# Altium schematic sheet Serial.SchDoc
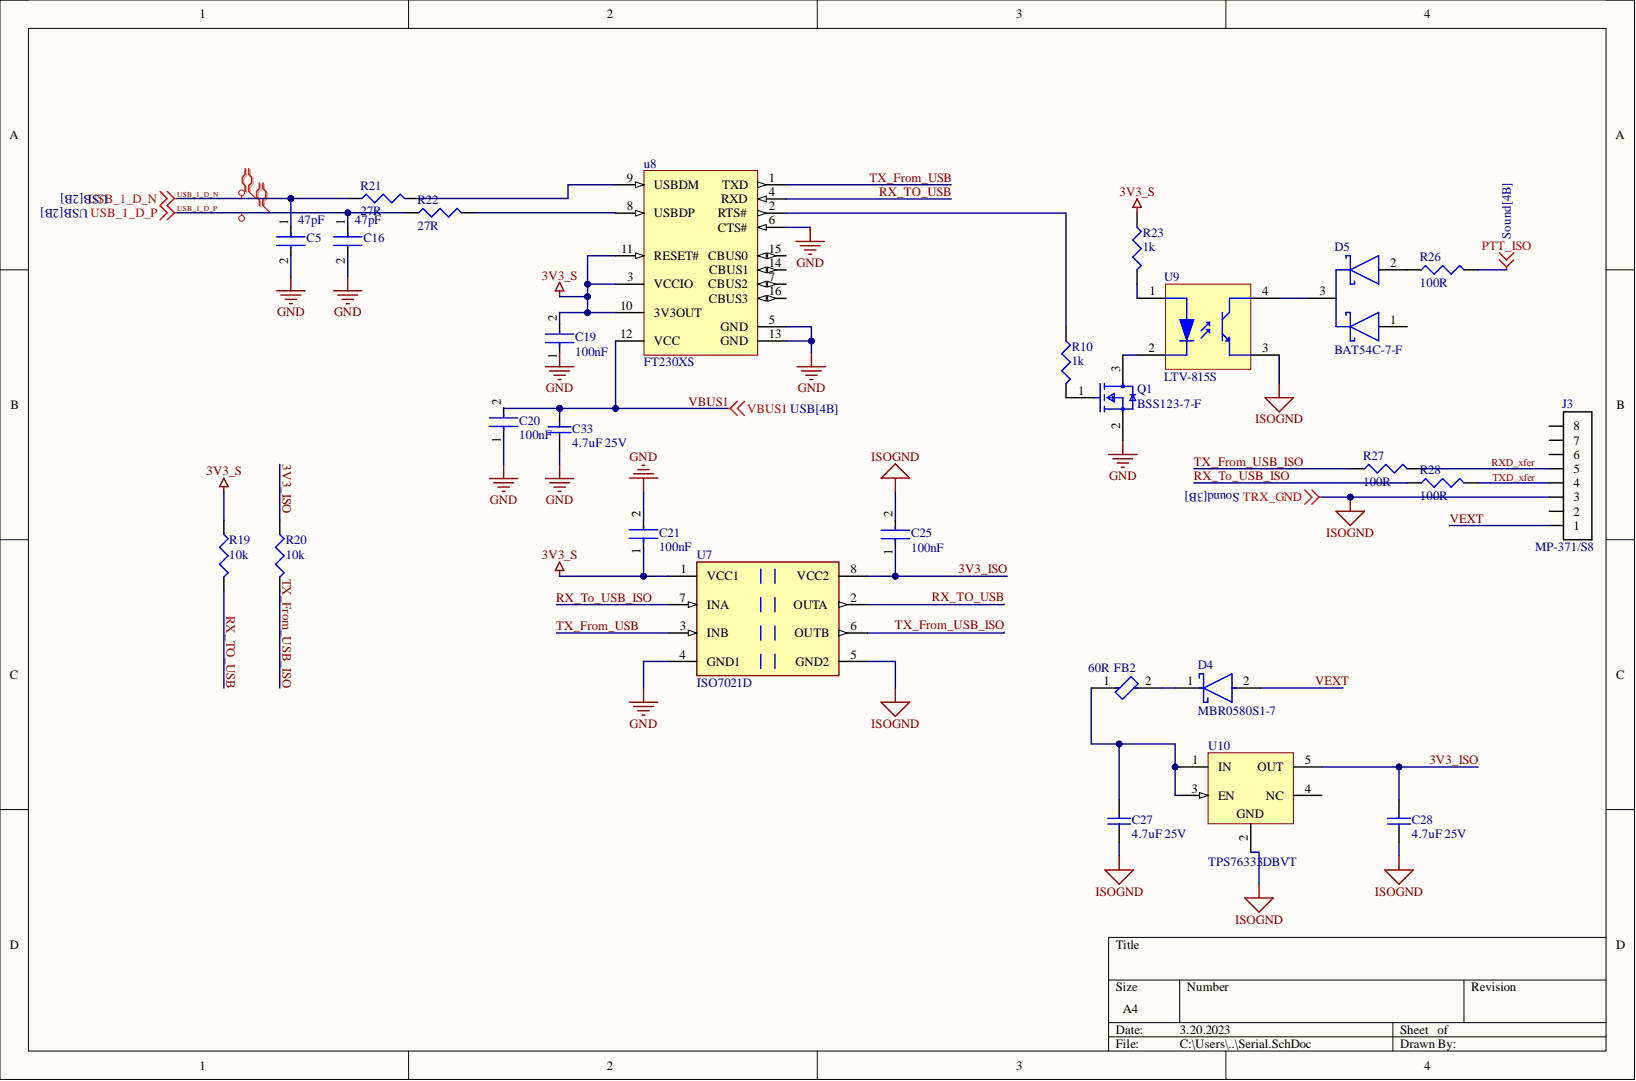
[diagram p0: Serial.SchDoc]

## Parts
C5  Capacitance: 47pF  [FP-CL10-IPC_C]  (hidden comment: CL10C470JC8NNNC)
C16  Capacitance: 47pF  [FP-CL10-IPC_C]  (hidden comment: CL10C470JC8NNNC)
C19  Capacitance: 100nF  [FP-CL10-IPC_B]  (hidden comment: CL10B104KC8NNNC)
C20  Capacitance: 100nF  [FP-CL10-IPC_B]  (hidden comment: CL10B104KC8NNNC)
C21  Capacitance: 100nF  [FP-CL10-IPC_B]  (hidden comment: CL10B104KC8NNNC)
C25  Capacitance: 100nF  [FP-CL10-IPC_B]  (hidden comment: CL10B104KC8NNNC)
C27  4.7uF 25V  value 4.7uF  [CAPC1210(3225)220_M]
C28  4.7uF 25V  value 4.7uF  [CAPC1210(3225)220_M]
C33  4.7uF 25V  value 4.7uF  [CAPC1210(3225)220_M]
D4  MBR0580S1-7  [FP-SOD123-MFG]
D5  BAT54C-7-F  [FP-SOT23-IPC_B]
FB2  Impedance: 60R  [FP-BLM41-0_2-IPC_B]  (hidden comment: BLM41PG600SN1L)
J3  MP-371/S8  [MiniDIN8_TopSolder_Lumberg]
Q1  BSS123-7-F  [FP-SOT23-IPC_C]
R10  1k  [J1-0603]
R19  10k  [J1-0603]
R20  10k  [J1-0603]
R21  27R  [J1-0603]
R22  27R  [J1-0603]
R23  1k  [J1-0603]
R26  100R  [J1-0603]
R27  100R  [J1-0603]
R28  100R  [J1-0603]
U7  ISO7021D  [FP-D0008A-IPC_B]
u8  FT230XS  [FTDI-SSOP-16_L]
U9  LTV-815S  [LTST-LTV-817S-4_V]
U10  TPS76333DBVT  [DBV0005A_N]

## Nets
3V3_S: C19.2 C21.1 R19.1 R23.1 U7.1(VCC1) u8.3(VCCIO) u8.10(3V3OUT) u8.11(RESET#)
GND: C5.2 C16.2 C19.1 C20.1 C21.2 C33.2 Q1.2 U7.4(GND1) u8.5(GND) u8.6(CTS#) u8.13(GND)
PTT_ISO: R26.2
TRX_GND: C25.2 C27.2 C28.2 J3.3 U7.5(GND2) U9.3 U10.2
3V3_ISO: C25.1 C28.1 R20.1 U7.8(VCC2) U10.5(OUT)
RX_TO_USB: R19.2 U7.2(OUTA) u8.4(RXD)
RX_To_USB_ISO: R28.1 U7.7(INA)
RXD_xfer: J3.5 R27.2
TX_From_USB: U7.3(INB) u8.1(TXD)
TX_From_USB_ISO: R20.2 R27.1 U7.6(OUTB)
TXD_xfer: J3.4 R28.2
USB_1_D_N: C5.1 R21.1
USB_1_D_P: C16.1 R22.1
VBUS1: C20.2 C33.1 u8.12(VCC)
VEXT: D4.2 J3.1
NetC27_1: C27.1 FB2.1 U10.1(IN) U10.3(EN)
NetD4_1: D4.1 FB2.2
NetD5_2: D5.2 R26.1
NetD5_3: D5.3 U9.4
NetQ1_1: Q1.1 R10.2
NetQ1_3: Q1.3 U9.2
NetR10_1: R10.1 u8.2(RTS#)
NetR21_2: R21.2 u8.9(USBDM)
NetR22_2: R22.2 u8.8(USBDP)
NetR23_2: R23.2 U9.1
Netu8_7: u8.7(CBUS2) U10.4(NC)

Not connected: D5 1; J3 2 6 7 8; u8 14(CBUS1) 15(CBUS0) 16(CBUS3)

## Library data of the parts
C5 C16 = CMP-13271-001416-1: Cap Ceramic 47pF 100V C0G ±5% Pad SMD 0603 +125°C T/R; Case Code (Imperial): 0603; Case Code (Metric): 1608; Case/Package: 0603; Component Kind: Standard; Depth: 0.8mm; Dielectric: C0G; Height: 0.8mm; JLCPCBPartNum: C1671; Length: 1.6mm; Library Name: Altium Content Vault; Material: Ceramic; Max Operating Temperature: 125°C; Min Operating Temperature: -55°C; Mount: Surface Mount; Packaging: Tape and Reel; Radiation Hardening: No; Thickness: 0.035inch; Tolerance: 5%; Voltage Rating: 100V; Voltage Rating (DC): 100V; Width: 0.031inch
C19-C21 C25 = CMP-13271-004036-1: Cap Ceramic 100nF 100V X7R ±10% Pad SMD 0603 +125°C T/R; Case Code (Imperial): 0603; Case Code (Metric): 1608; Case/Package: 0603; Component Kind: Standard; JLCPCBPartNum: C15725; Length: 0.063inch; Library Name: Altium Content Vault; Max Operating Temperature: 125°C; Min Operating Temperature: -55°C; Mount: Surface Mount; Packaging: Cut Tape; Thickness: 0.035inch; Tolerance: 10%; Voltage Rating: 100V; Width: 0.031inch
C27 C28 C33 = CMP-1038-02151-2: CAP 4.7uF 25V ±10% 1210 (3225 Metric) Thickness 2.2mm SMD; Case-EIA: 1210; Case-Metric: 3225; Tolerance: Â±10%; Component Kind: Standard; JLCPCBPartNum: C86052; Library Name: Altium Content Vault; Max Thickness: 2.2 mm; Rated Voltage: 25 V; Technology: SMT
D4 = CMP-12790-000046-1: DIODE SCHOTTKY 80V 500MA SOD123; Manufacturer: Diodes; Capacitance: 15pF; Forward Current: 0.5A; Max Junction Temp: +175°C; Max Surge Forward Current: 14A; Peak Reverse Current: 0.5uA; Standoff Height: 0mm; Value: 15pF; Automotive: No; Category: Diode; Component Kind: Standard; ComponentLink2Description: Datasheet; ComponentLink2URL: https://www.diodes.com/assets/Datasheets/MBR0580S1.pdf; Device Class L1: Discrete Semiconductors; Device Class L2: Diodes; Device Class L3: Schottky Diodes; Digikey Description: DIODE SCHOTTKY 80V 500MA SOD123; Footprint Url: https://www.diodes.com/assets/Package-Files/SOD123.pdf; Height: 1.45mm; JLCPCBPartNum: C177047; Lead Free: Yes; Library Name: Altium Content Vault; Manufacturer Part Number: MBR0580S1-7; Max Repetitive Reverse Voltage: 80V; Mouser Description: Schottky Diodes & Rectifiers SBR 0.5A 80Vrrm 14A Ifsm 80Vrrm 15pF; Octopart: https://octopart.com/mbr0580s1-7-diodes+inc.-53081572; Package: SOD123; Reverse Voltage: 80V; RoHS: TRUE; Temperature: -55°C to +175°C; Temperature Range High: +175°C; Temperature Range Low: -55°C
D5 = CMP-12790-000084-1: DIODE ARRAY SCHOTTKY 30V SOT23-3; Average Rectified Current: 200mA; Capacitance: 10pF; Element Configuration: Common Cathode; Forward Voltage: 800mV; Max Forward Surge Current (Ifsm): 600mA; Max Power Dissipation: 200mW; Max Reverse Current: 2uA; Reverse Recovery Time: 5ns; Case/Package: SOT-23; Component Kind: Standard; Contact Plating: Tin; Current Rating: 200mA; Forward Current: 200mA; Height: 1mm; JLCPCBPartNum: C134405; Lead Free: Lead Free; Length: 2.9mm; Library Name: Altium Content Vault; Max Operating Temperature: 150°C; Max Repetitive Reverse Voltage (Vrrm): 30V; Max Reverse Voltage (DC): 30V; Min Operating Temperature: -65°C; Mount: Surface Mount; Number of Pins: 3; Package Quantity: 3000; Packaging: Tape and Reel; Peak Non-Repetitive Surge Current: 600mA; Power Dissipation: 200mW; Power Rating: 200mW; Radiation Hardening: No; REACH SVHC: No SVHC; RoHS Compliant: Yes; Series: -; Termination: SMD/SMT; Voltage Rating (DC): 30V; Weight: 0.000282oz; Width: 1.3mm
FB2 = CMP-0686-00754-3: Ferrite Bead, 1806, 60Ω @ 100MHz, 0.009Ω, 6A; Manufacturer Series: BLM41P; DC Resistance (DCR): 9mR; Number of Circuits: 1; Shielding: Unshielded; Tolerance: 25%; Case Code (Imperial): 1806; Case Code (Metric): 4516; Case/Package: 1806; Component Kind: Standard; Contact Plating: Tin; Core Material: Ferrite; Current: 6A; Current Rating: 6A; DC Current: 6A; DC Resistance-Max: 9mR; Depth: 1.6mm; Frequency: 100MHz; Height: 1.6mm; Inductor Type: FERRITE BEAD; JLCPCBPartNum: C85844; Lead Free: Lead Free; Length: 4.5mm; Library Name: Altium Content Vault; Material: Ferrite; Max Current Rating: 6A; Max DC Current: 6A; Max Operating Temperature: 125°C; Military Standard: Not; Min Operating Temperature: -55°C; Number of Functions: 1; Number of Lines: 1; Number of Pins: 2; Package Quantity: 1; Packaging: Tape and Reel; Radiation Hardening: No; REACH SVHC: No SVHC; RoHS Compliant: Yes; Series: BLM41P; Terminal Finish: Tin; Termination: SMD/SMT; Test Frequency: 100MHz; Width: 1.6mm
J3 = MiniDin8_SMT: Miniature circular connectors MP-371/S8; Component Kind: Standard; Digikey_PartNum: 2057-MDP-008-ND; Library Name: Schlib1.SchLib
Q1 = CMP-12801-000017-1: MOSFET N-CH 100V 170MA SOT23-3; Manufacturer: Diodes Incorporated; Automotive Grade: NA; Depletion Mode: False; Drain To Source Resistance: 6Ω; Gate To Source Voltage: 20V; Input Capacitace At Vds: 22pF @ 25V; Max Forward Diode Voltage: 1.3V; Max Junction Temp: +150°C; Number Of Channels: 1; Power Dissipation: 300mW; Pulse Drain Current: 680mA; Rthja Max: 417°C/W; Standoff Height: 0.013mm; Threshold Vgs Max: 2V; Threshold Vgs Min: 0.8V; Transconductance: 0.37S; Turn Off Delay Time: 13ns; Turn On Delay Time: 8ns; Typ Forward Diode Voltage: 0.84V; Automotive: No; Category: Trans; Component Kind: Standard; ComponentLink2Description: Datasheet; ComponentLink2URL: https://www.diodes.com/assets/Datasheets/ds30366.pdf; Continuous Drain Current: 170mA; Device Class L1: Discrete Semiconductors; Device Class L2: Transistors; Device Class L3: MOSFETs; Digikey Description: MOSFET N-CH 100V 170MA SOT23-3; Drain To Source Breakdown Voltage: 100V; Drain To Source Voltage: 100V; Footprint Url: https://www.diodes.com/assets/Package-Files/SOT23.pdf; Height: 1.1mm; JLCPCBPartNum: C85107; Lead Free: Yes; Library Name: Altium Content Vault; Manufacturer Part Number: BSS123-7-F; Mouser Description: MOSFET 100V 360mW; Number Of N Channels: 1; Octopart: https://octopart.com/bss123-7-f-diodes+inc.-335886; Package: SOT23; RoHS: TRUE; Temperature: -55°C to +150°C; Temperature Range High: +150°C; Temperature Range Low: -55°C
R10 R19-R23 R26-R28 = Res3: Resistor; Component Kind: Standard; LatestRevisionDate: 29-May-2009; LatestRevisionNote: IPC-7351 Footprint Added.; Library Name: Miscellaneous Devices.IntLib; PackageDescription: Chip Resistor; PackageReference: J1-0603; Published: 8-Jun-2000; Signal Integrity: Res; Simulation: RESISTOR; Publisher: Altium Limited
  R10 R23: JLCPCBPartNum: C21190; Value: 1k
  R19 R20: JLCPCBPartNum: C25804; Value: 10k
  R21 R22: JLCPCBPartNum: C192531; Value: 27R
  R26-R28: JLCPCBPartNum: C22775; Value: 100R
U7 = CMP-04872-000022-1: DGTL ISO 3000VRMS 2CH GP 8SOIC; Component Kind: Standard; Library Name: Altium Content Vault
u8 = CMP-2000-06288-1: USB to Basic UART IC, 2.97 to 5.5 V, -40 to 85 degC, 16-Pin SSOP, RoHS; Manufacturer: FTDI; Data Rate: 3Mbaud; No. of Pins: 16Pins; Supply Voltage Max: 5.5V; Supply Voltage Min: 2.97V; SVHC: NoSVHC(17-Dec-2015); USB Standard: USB2.0; USB Type: Transceiver; Component Kind: Standard; Datasheet URL: http://www.ftdichip.com/Support/Documents/DataSheets/ICs/DS_FT230X.pdf; Datasheet Version: Rev. 1.4, 05/2016; Interface Case Style: SSOP; JLCPCBPartNum: C69082; Library Name: Altium Content Vault; Manufacturer URL: http://www.ftdichip.com/; Mounting Technology: SurfaceMount; MSL: MSL3-168hours; No. of Ports: -; Operating Temperature Max: 85°C; Operating Temperature Min: -40°C; Package Description: 16-Pin Shrink Small Outline Package Integrated Circuit, Body 4.9 x 3.9 mm, Pitch 0.635 mm; Package Reference: SSOP-16; Packaging: CutTape; Product Range: -
U9 = CMP-2000-05204-1: Photocoupler - Phototransistor Output, 35 V VCEO, -50 to 110 degC, 4-Pin SMD, RoHS, Tape and Reel; Manufacturer: Lite-On; Collector Current (DC): 0.05A; Collector-Emitter Saturation Voltage: 0.2V; Collector-Emitter Voltage: 35V; Current Transfer Ratio: 600%; DELETED: Compliant; Fall Time: 18000ns; Forward Current: 50mA; Forward Voltage: 1.4V; Input Type: DC; Isolation Voltage: 5000V; Number of Elements: 1; Power Dissipation: 0.2W; Rad Hardened: No; Reverse Breakdown Voltage: 6V; Collector Current (DC) (Max): 0.05A; Component Kind: Standard; Datasheet Version: Jan-10; JLCPCBPartNum: C115455; Library Name: Altium Content Vault; Manufacturer URL: http://www.us.liteon.com/; Mounting: SurfaceMount; Operating Temp Range: -30Cto100C; Operating Temperature Classification: Commercial; Output Device: Transistor; Output Type: DC; Package Type: PDIPSMD; Pin Count: 4; Rise Time: 18000ns
U10 = CMP-0394-00563-2: Single Output LDO, 150 mA, Fixed 3.3 V Output, 2.7 to 10 V Input, with Low IQ, 5-pin SOT-23 (DBV), -40 to 125 degC, Green (RoHS & no Sb/Br); Manufacturer: Texas Instruments; Accuracy(%): 3; Fixed Output Options(V): 3.3; Iout(Max)(A): 0.15; Iq(Typ)(mA): 0.08; SubFamily: Single Channel LDO; Vdo(Typ)(mV): 300; Vin(Max)(V): 10; Vin(Min)(V): 2.7; Code_JEDEC: MO-178; Component Kind: Standard; ComponentLink1Description: Manufacturer URL; ComponentLink1URL: http://www.ti.com/; ComponentLink2Description: Datasheet; ComponentLink2URL: http://www.ti.com/general/docs/lit/getliterature.tsp?genericPartNumber=TPS76333&fileType=pdf; ComponentLink3Description: Package Specification; ComponentLink3URL: http://www.ti.com/litv/pdf/mpds018i; DatasheetVersion: SLVS181H; Eco-Plan: Green (RoHS & no Sb/Br); JLCPCBPartNum: C7100; Library Name: Altium Content Vault; Mounting Technology: Surface Mount; PackageDescription: 5-Pin Plastic Small Outline, Body 1.60 Ãƒâ€” 2.90 mm, Pitch 0.95 mm; PackageReference: DBV0005A; PackageVersion: 4073253-4/K; PartNumber: TPS76333DBVT; Vout Adj(Max)(V): 3.3; Vout Adj(Min)(V): 3.3
